# Altium schematic sheet RibbonCable.SchDoc(RibbonCable)
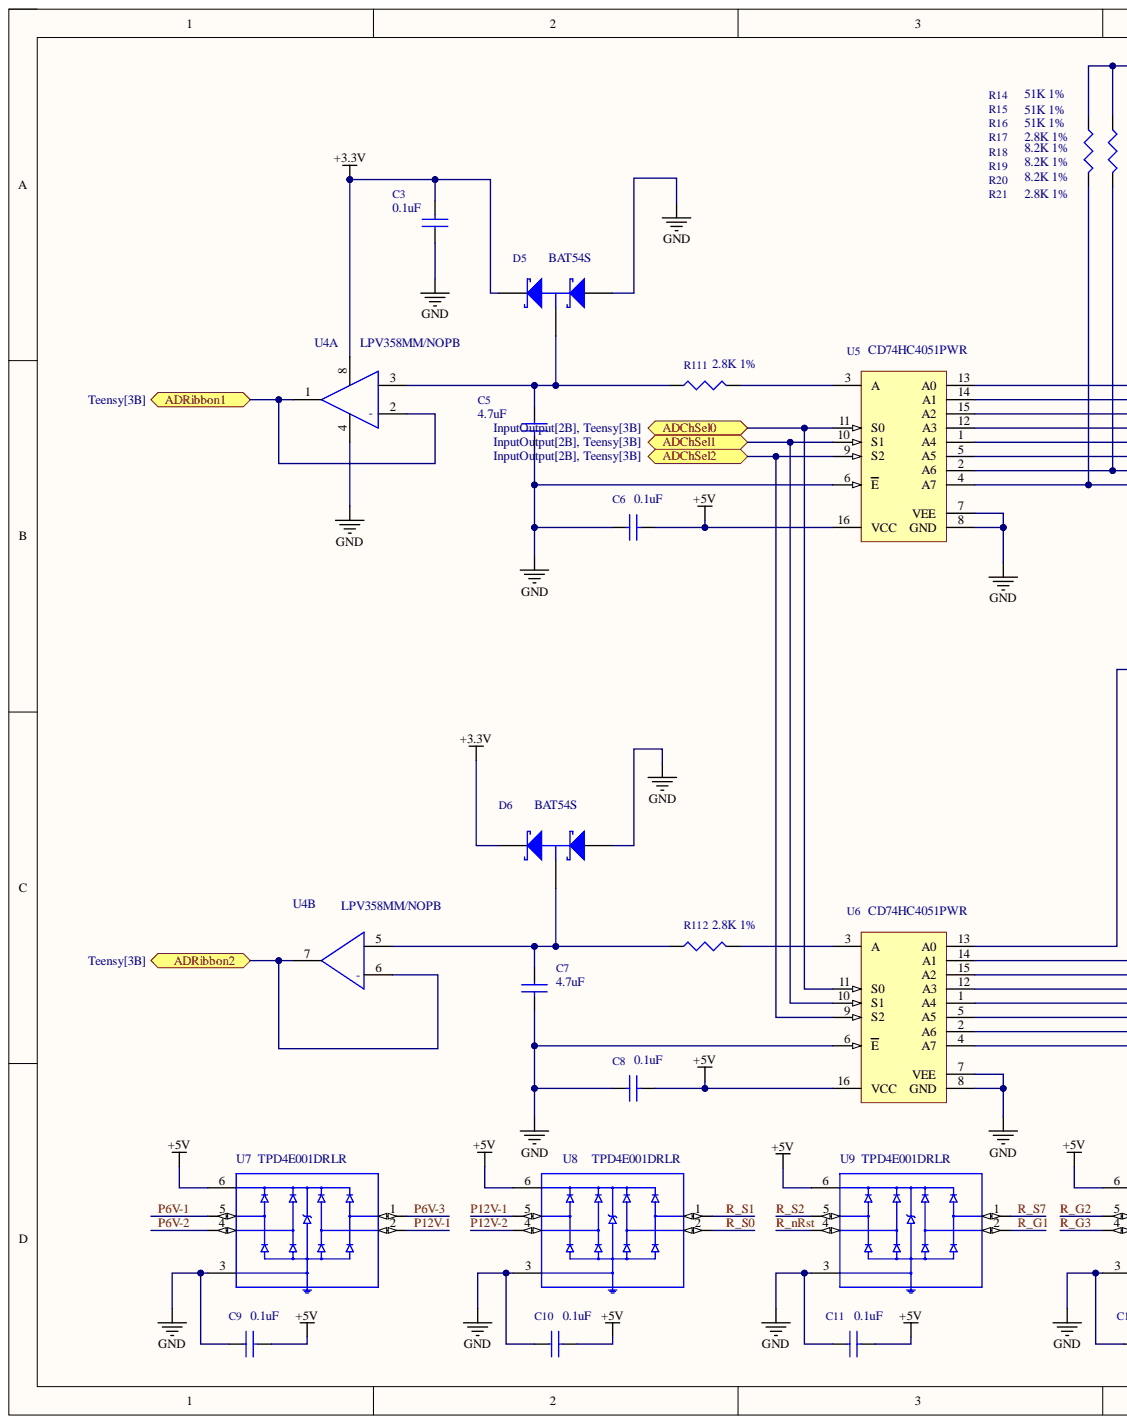
[diagram p0: RibbonCable.SchDoc, left]
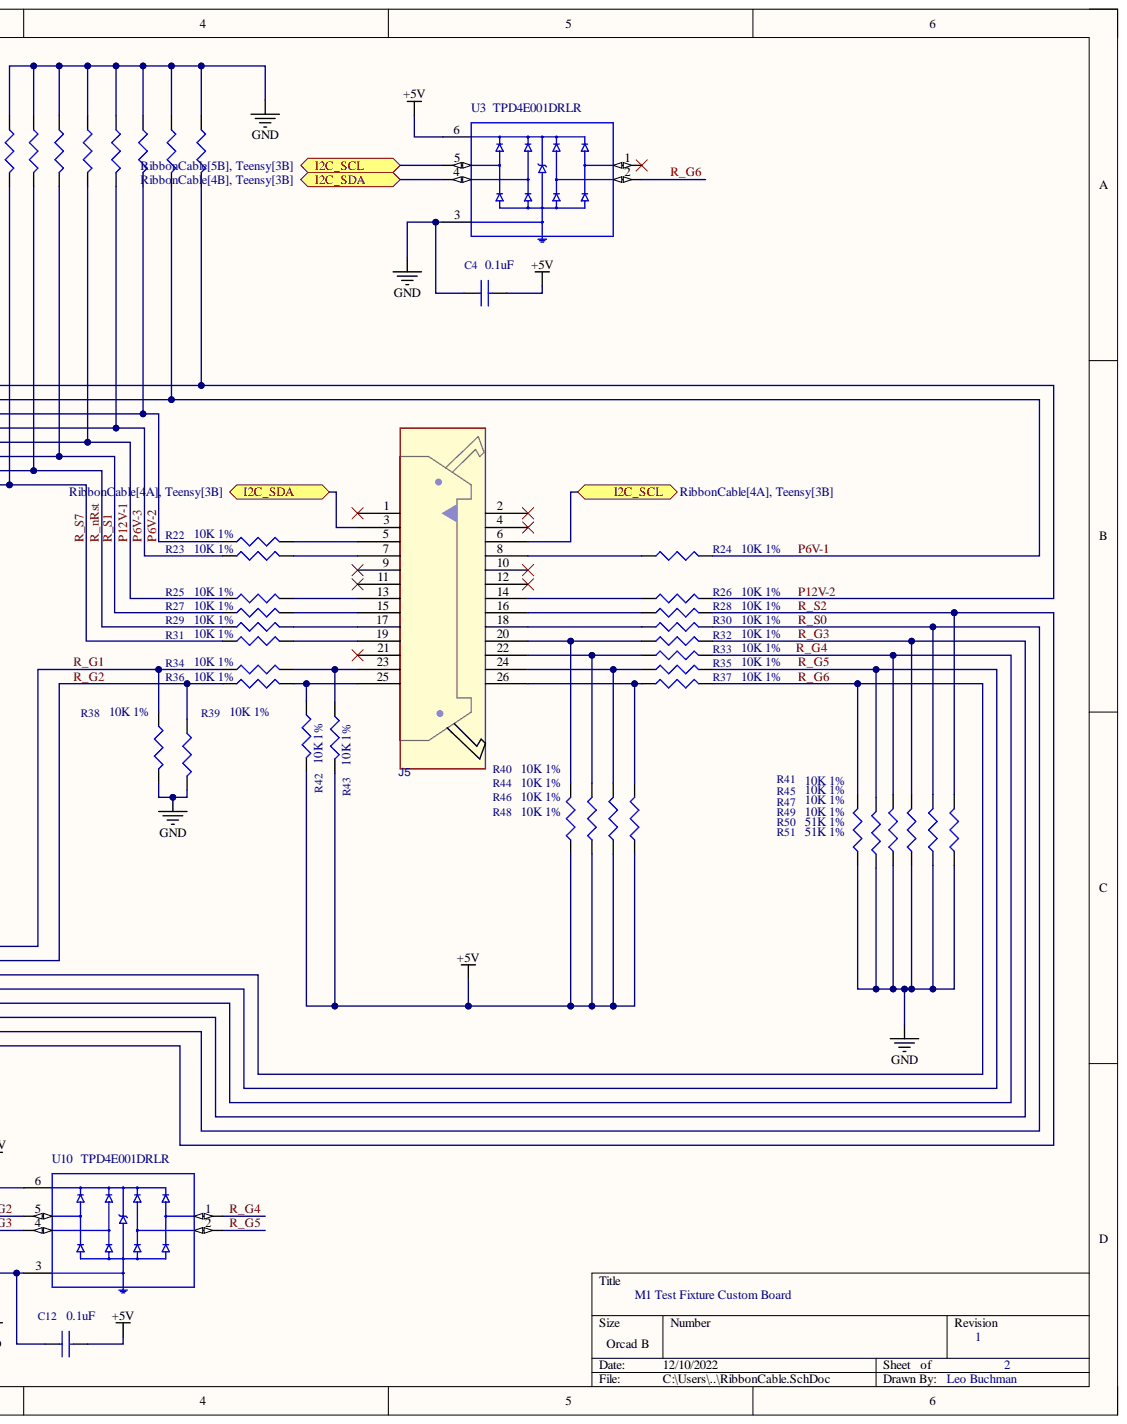
[diagram p1: RibbonCable.SchDoc, right]

## Parts
C3  0.1uF  [C0402]
C4  0.1uF  [C0402]
C5  4.7uF  [C0603]
C6  0.1uF  [C0402]
C7  4.7uF  [C0603]
C8  0.1uF  [C0402]
C9  0.1uF  [C0402]
C10  0.1uF  [C0402]
C11  0.1uF  [C0402]
C12  0.1uF  [C0402]
D5  BAT54S  [sot23-3]
D6  BAT54S  [sot23-3]
J5  N3429-5202RB  [CONN_N3429-5202RB]  (value not drawn)
R14  51K 1%  Tolerance: 1%  [R0402]
R15  51K 1%  [R0402]
R16  51K 1%  [R0402]
R17  2.8K 1%  [R0402]
R18  8.2K 1%  [R0402]
R19  8.2K 1%  [R0402]
R20  8.2K 1%  [R0402]
R21  2.8K 1%  [R0402]
R22  10K 1%  Tolerance: 1%  [R0402]
R23  10K 1%  Tolerance: 1%  [R0402]
R24  10K 1%  [R0402]
R25  10K 1%  Tolerance: 1%  [R0402]
R26  10K 1%  [R0402]
R27  10K 1%  Tolerance: 1%  [R0402]
R28  10K 1%  [R0402]
R29  10K 1%  Tolerance: 1%  [R0402]
R30  10K 1%  [R0402]
R31  10K 1%  Tolerance: 1%  [R0402]
R32  10K 1%  [R0402]
R33  10K 1%  [R0402]
R34  10K 1%  Tolerance: 1%  [R0402]
R35  10K 1%  [R0402]
R36  10K 1%  Tolerance: 1%  [R0402]
R37  10K 1%  [R0402]
R38  10K 1%  Tolerance: 1%  [R0402]
R39  10K 1%  [R0402]
R40  10K 1%  Tolerance: 1%  [R0402]
R41  10K 1%  Tolerance: 1%  [R0402]
R42  10K 1%  Tolerance: 1%  [R0402]
R43  10K 1%  Tolerance: 1%  [R0402]
R44  10K 1%  [R0402]
R45  10K 1%  [R0402]
R46  10K 1%  [R0402]
R47  10K 1%  [R0402]
R48  10K 1%  [R0402]
R49  10K 1%  [R0402]
R50  51K 1%  [R0402]
R51  51K 1%  [R0402]
R111  2.8K 1%  Tolerance: 1%  [R0402]
R112  2.8K 1%  Tolerance: 1%  [R0402]
U3  TPD4E001DRLR  [SOTFL50P160X60-6N]
U4  LPV358MM/NOPB  [MUA08A]  (parts A,B)
U5  CD74HC4051PWR  [16-TSSOP-4.4mm]
U6  CD74HC4051PWR  [16-TSSOP-4.4mm]
U7  TPD4E001DRLR  [SOTFL50P160X60-6N]
U8  TPD4E001DRLR  [SOTFL50P160X60-6N]
U9  TPD4E001DRLR  [SOTFL50P160X60-6N]
U10  TPD4E001DRLR  [SOTFL50P160X60-6N]

## Ports
ADChSel0, ADChSel1, ADChSel2, ADRibbon1, ADRibbon2, I2C_SCL, I2C_SDA

## Nets
+3.3V: C3.2 D5.2 D6.2 U4.8
+5V: C4.2 C6.2 C8.2 C9.2 C10.2 C11.2 C12.2 R40.1 R42.2 R43.2 R44.1 R46.1 R48.1 U3.6 U5.16(VCC) U6.16(VCC) U7.6 U8.6 U9.6 U10.6
GND: C3.1 C4.1 C5.2 C6.1 C7.2 C8.1 C9.1 C10.1 C11.1 C12.1 D5.1 D6.1 R14.1 R15.1 R16.1 R17.1 R18.1 R19.1 R20.1 R21.1 R38.2 R39.2 R41.1 R45.1 R47.1 R49.1 R50.1 R51.1 U3.3 U4.4 U5.6(E) U5.7(VEE) U5.8(GND) U6.6(E) U6.7(VEE) U6.8(GND) U7.3 U8.3 U9.3 U10.3
ADChSel0: U5.11(S0) U6.11(S0) ; port ADChSel0
ADChSel1: U5.10(S1) U6.10(S1) ; port ADChSel1
ADChSel2: U5.9(S2) U6.9(S2) ; port ADChSel2
ADRibbon1: U4.1 U4.2(-) ; port ADRibbon1
ADRibbon2: U4.6(-) U4.7 ; port ADRibbon2
I2C_SCL: J5.6 U3.5 ; port I2C_SCL
I2C_SDA: J5.3 U3.4 ; port I2C_SDA
P6V-1: R20.2 R24.2 U5.14(A1) U7.5
P6V-2: R19.2 R22.1 U5.15(A2) U7.4
P6V-3: R18.2 R23.1 U5.12(A3) U7.1
P12V-1: R17.2 R25.1 U5.1(A4) U7.2 U8.5
P12V-2: R21.2 R26.2 U5.13(A0) U8.4
R_G1: R34.1 R38.1 U6.13(A0) U9.2
R_G2: R36.1 R39.1 U6.14(A1) U10.5
R_G3: R32.2 R49.2 U6.5(A5) U10.4
R_G4: R33.2 R47.2 U6.1(A4) U10.1
R_G5: R35.2 R45.2 U6.12(A3) U10.2
R_G6: R37.2 R41.2 U3.2 U6.15(A2)
R_nRst: R15.2 R29.1 U5.2(A6) U9.4
R_S0: R30.2 R50.2 U6.2(A6) U8.2
R_S1: R16.2 R27.1 U5.5(A5) U8.1
R_S2: R28.2 R51.2 U6.4(A7) U9.5
R_S7: R14.2 R31.1 U5.4(A7) U9.1
NetC5_1: C5.1 D5.3 R111.1 U4.3
NetC7_1: C7.1 D6.3 R112.1 U4.5
NetJ5_5: J5.5 R22.2
NetJ5_7: J5.7 R23.2
NetJ5_8: J5.8 R24.1
NetJ5_13: J5.13 R25.2
NetJ5_14: J5.14 R26.1
NetJ5_15: J5.15 R27.2
NetJ5_16: J5.16 R28.1
NetJ5_17: J5.17 R29.2
NetJ5_18: J5.18 R30.1
NetJ5_19: J5.19 R31.2
NetJ5_20: J5.20 R32.1 R40.2
NetJ5_22: J5.22 R33.1 R44.2
NetJ5_23: J5.23 R34.2 R43.1
NetJ5_24: J5.24 R35.1 R46.2
NetJ5_25: J5.25 R36.2 R42.1
NetJ5_26: J5.26 R37.1 R48.2
NetR111_2: R111.2 U5.3(A)
NetR112_2: R112.2 U6.3(A)

Not connected: J5 1 2 4 9 10 11 12 21; U3 1

## Library data of the parts
C3 C4 C6 C8-C12 = Cap-00005: Cap Ceramic 0.1uF 50V X7R 10% Pad SMD 0402 125C Automotive T/R; Manufacturer: Murata; Manufacturer Part Number: GRT155R71H104KE01D; Database Table Name: Capacitors; Case Code (Imperial): 0402; Case Code (Metric): 1005; Case/Package: 0402; Category: Ceramic Capacitors; Component Kind: Standard; Dielectric Material: Ceramic; LCSC Part Number: C307331; Mount: Surface Mount; Number of Pins: 2; Part Name: Cap-00005; Pricing 1: 1=0.10000, 10=0.04700, 50=0.02580, 100=0.0211; RoHS: Yes; RoHS Compliant: Yes; Stock 1: 179948; Supplier 1: Digi-Key; Supplier Part Number 1: 490-GRT155R71H104KE01DCT-ND; Voltage Rating (DC): 50V; Library Name: Leo-Home-SQL-Lib.DbLib
C5 C7 = Cap-00001: CAP CER 4.7UF 16V X5R 0603; Manufacturer: Samsung; Manufacturer Part Number: CL10A475KO8NNNC; Database Table Name: Capacitors; Dielectric Material: Ceramic; Tolerance: 10%; Capacitance: 4.7uF; Case Code (Imperial): 0603; Case Code (Metric): 1608; Case/Package: 0603; Category: Ceramic Capacitors; Component Kind: Standard; ComponentLink1Description: https://datasheet.ciiva.com/25795/cl10a475ko8; ComponentLink1URL: https://datasheet.ciiva.com/25795/cl10a475ko8; ComponentLink2Description: https://datasheet.ciiva.com/26838/getdatashee; ComponentLink2URL: https://datasheet.ciiva.com/26838/getdatashee; ComponentLink3Description: https://datasheet.ciiva.com/29198/457663-da-0; ComponentLink3URL: https://datasheet.ciiva.com/29198/457663-da-0; Construction: Flat; Contact Plating: Tin; Depth: 0.8mm; Dielectric: X5R; Height: 0.8mm; LCSC Part Number: C19666; Length: 1.6mm; Material: Ceramic; Max Operating Temperature: 85°C; Min Operating Temperature: -55°C; Mount: Surface Mount; Number of Pins: 2; Package Quantity: 1; Packaging: Tape and Reel; Part Name: Cap-00001; Pricing 1: 1=0.13000, 10=0.09100, 50=0.05180, 100=0.0429; Radiation Hardening: No; RoHS: Yes; RoHS Compliant: Yes; Series: CL; Stock 1: 1593998; Supplier 1: Digi-Key; Supplier Part Number 1: 1276-1784-1-ND; Thickness: 0.035inch; Voltage: 16V; Voltage Rating: 16V; Width: 0.031inch; Library Name: Leo-Home-SQL-Lib.DbLib
D5 D6 = Diode-0009: DIODE SCHOTTKY 30V 150MA SOT23-3; Manufacturer: Sangdest Microelectronics; Database Table Name: Diodes; Elements: 2; Package Version: Rev. 12, 03/2016; Peak Reverse Current: 2uA; Recovery Time: 5ns; Component Kind: Standard; Component Type: Diodes; Datasheet URL: https://www.fairchildsemi.com/datasheets/BA/BAT54S.pdf; Datasheet Version: Rev. 2.3, 04/2016; LCSC Part Number: C47546; Lead Free: Lead Free; Manufacturer Part Number: BAT54S; Manufacturer URL: https://www.fairchildsemi.com/; Mount: Surface Mount; Mounting Technology: SM; Package Description: 3-Pin Small Outline Transistor, Body 2.92 x 1.325 mm, Pitch 0.95 mm; Package Reference: SOT23-3; Package URL: http://www.fairchildsemi.com/dwg/MA/MA03D.pdf; Part Name: Diode-0009; Pins: 3; Pricing 1: 1=0.43000, 10=0.35100, 100=0.18620, 500=0.122; Radiation Hardening: No; RoHS: Yes; Stock 1: 168252; Supplier 1: Digi-Key; Supplier Part Number 1: 1655-BAT54SCT-ND; Library Name: Leo-Home-SQL-Lib.DbLib
J5 = CON-0010: 3000 Series; 2.54mm Pitch 26 Way 2 Row Right; Manufacturer: 3M; ATTR: CONN HEADER LATCH/EJEC  RTA DUAL ROW 26POS; Closed End: No (Without); Connector Type: Male Pin; Current: 12A; Current Rating: 1A; Database Table Name: Connectors; Gender: Male; Interlock: Yes (With); Max Current Rating: 2A; Max Supply Voltage (AC): 300V; Number of Circuits: 8; Number of Contacts: 26; Number of Levels: 1; PKG: 2M54_13x2_TH_LATCH; Plating: Brass; Row Spacing: 0.1inch; Tail Length: 3.5mm; Thread Size: M2; Wire Gauge (Max): 30AWG; Category: Headers and Wire Housings; Color: Black; Component Kind: Standard; ComponentLink1Description: https://datasheet.ciiva.com/19461/1578947-194; ComponentLink1URL: https://datasheet.ciiva.com/19461/1578947-194; ComponentLink2Description: https://datasheet.ciiva.com/11754/0900766b807; ComponentLink2URL: https://datasheet.ciiva.com/11754/0900766b807; ComponentLink3Description: https://datasheet.ciiva.com/719/ts0772-719341; ComponentLink3URL: https://datasheet.ciiva.com/719/ts0772-719341; ComponentLink4Description: https://datasheet.ciiva.com/8051/ts0772-80510; ComponentLink4URL: https://datasheet.ciiva.com/8051/ts0772-80510; ComponentLink5Description: https://datasheet.ciiva.com/30156/eng-cs-1308; ComponentLink5URL: https://datasheet.ciiva.com/30156/eng-cs-1308; ComponentLink6Description: https://datasheet.ciiva.com/3141/eng-cs-13083; ComponentLink6URL: https://datasheet.ciiva.com/3141/eng-cs-13083; ComponentLink7Description: https://datasheet.ciiva.com/823/eng-cd-284391; ComponentLink7URL: https://datasheet.ciiva.com/823/eng-cd-284391; Contact Material: Copper Alloy,Copper; Contact Mating Length: 0.24inch; Contact Plating: Gold; Contact Type: Male Pin; Depth: 24.6mm; ELV: Compliant; Fastening Type: Latch; Flammability Rating: UL94 V-0; Height: 8.6mm; Housing Color: Black; Housing Material: Polychlorinated; LCSC Part Number: N/A; Lead Free: Lead Free; Length: 52.45mm; Manufacturer Part Number: N3429-5202RB; Max Operating Temperature: 105°C; Max Voltage Rating (AC): 300V; Min Operating Temperature: -55°C; Mount: Through Hole; Number of Positions: 26; Number of Positions Per Level: 8; Number of Rows: 2; Orientation: Right Angle; Package Quantity: 250; Packaging: Bulk; Part Name: CON-0010; Pitch: 2.54mm; Pricing 1: 1=5.34000, 10=4.85100, 100=4.27430, 500=3.633; Radiation Hardening: No; REACH SVHC: No SVHC; RoHS: Yes; RoHS Compliant: Yes; Screw Flange: No (Without); Screwless Terminal Block: No (Without); Series: 3000; Shrouded: Yes (With); Side Stackable: Yes (With); Stock 1: 185; Supplier 1: Digi-Key; Supplier Part Number 1: MHR26S-ND; Termination: Solder; Voltage: 300V; Voltage Rating: 300V; Wire Gauge (Min): 30AWG; Library Name: Leo-Home-SQL-Lib.DbLib
R14-R16 R50 R51 = Res-00011: Res Thick Film 0402 51K Ohm 1% 0.063W(1/16W) ±100ppm/C Pad SMD Automotive T/R; Manufacturer: Stackpole Electronics; Manufacturer Part Number: RMCF0402FT51K0; Database Table Name: Resistors; Max Power Dissipation: 63mW; Resistance: 51kR; Temperature Coefficient: 100ppm/°C; Case Code (Imperial): 0402; Case Code (Metric): 1005; Case/Package: 0402; Category: Chip SMD Resistors; Component Kind: Standard; ComponentLink1Description: http://components.arrow.com/part/dataview/535; ComponentLink1URL: http://components.arrow.com/part/dataview/535; ComponentLink2Description: https://www.seielect.com/catalog/SEI-RMCF_RMC; ComponentLink2URL: https://www.seielect.com/catalog/SEI-RMCF_RMC; ComponentLink3Description: https://www.seielect.com/Catalog/SEI-RMCF_RMC; ComponentLink3URL: https://www.seielect.com/Catalog/SEI-RMCF_RMC; ComponentLink4Description: https://www.seielect.com/catalog/SEI-Packagin; ComponentLink4URL: https://www.seielect.com/catalog/SEI-Packagin; Contact Plating: Tin,Matte; Features: Automotive AEC-Q200; Height: 0.016inch; LCSC Part Number: C25794; Length: 0.039inch; Max Operating Temperature: 155°C; Min Operating Temperature: -55°C; Mount: Surface Mount; Number of Terminations: 2; Packaging: Tape and Reel; Part Name: Res-00011; Power Rating: 63mW; Pricing 1: 1=0.10000, 10=0.01500, 100=0.00610, 1000=0.00; Radiation Hardening: No; Resistor Type: Thick Film; RoHS: Yes; RoHS Compliant: Yes; Series: RMCF; Stock 1: 588931; Supplier 1: Digi-Key; Supplier Part Number 1: RMCF0402FT51K0CT-ND; Width: 0.02inch; Library Name: Leo-Home-SQL-Lib.DbLib
  R15 R16 R50 R51: Tolerance: 1%
R17 R21 R111 R112 = Res-00008: Thick Film 0402 2.8K Ohm 1% 0.063W ±100ppm/°C Molded SMD Paper T/R; Manufacturer: Stackpole Electronics; Manufacturer Part Number: RMCF0402FT2K80; Database Table Name: Resistors; Max Power Dissipation: 63mW; Power Rating: 62.5mW; Resistance: 2.8kR; Case Code (Imperial): 0402; Case Code (Metric): 1005; Case/Package: 0402; Category: Chip SMD Resistors; Component Kind: Standard; ComponentLink1Description: https://www.seielect.com/catalog/SEI-RMCF_RMC; ComponentLink1URL: https://www.seielect.com/catalog/SEI-RMCF_RMC; ComponentLink2Description: https://www.seielect.com/Catalog/SEI-RMCF_RMC; ComponentLink2URL: https://www.seielect.com/Catalog/SEI-RMCF_RMC; ComponentLink3Description: https://www.seielect.com/catalog/SEI-Packagin; ComponentLink3URL: https://www.seielect.com/catalog/SEI-Packagin; Contact Plating: Tin,Matte; Features: Automotive AEC-Q200; Height: 0.016inch; LCSC Part Number: C62741; Lead Free: Lead Free; Length: 0.039inch; Max Operating Temperature: 155°C; Min Operating Temperature: -55°C; Mount: Surface Mount; Number of Terminations: 2; Packaging: Tape and Reel; Part Name: Res-00008; Pricing 1: 1=0.10000, 10=0.01500, 100=0.00610, 1000=0.00; Radiation Hardening: No; Resistor Type: Thick Film; RoHS: Yes; RoHS Compliant: Yes; Series: RMCF; Stock 1: 141882; Supplier 1: Digi-Key; Supplier Part Number 1: RMCF0402FT2K80CT-ND; Temperature Coefficient: 100ppm/°C; Width: 0.02inch; Library Name: Leo-Home-SQL-Lib.DbLib
  R17 R21: Tolerance: 1%
R18-R20 = Res-00012: Res Thick Film 0402 8.2K Ohm 5% 0.063W ±100ppm/°C Molded SMD Paper T/R; Manufacturer: Stackpole Electronics; Manufacturer Part Number: RMCF0402JT8K20; Database Table Name: Resistors; Max Power Dissipation: 63mW; Resistance: 8.2kR; Case Code (Imperial): 0402; Case Code (Metric): 1005; Case/Package: 0402; Category: Chip SMD Resistors; Component Kind: Standard; ComponentLink1Description: https://www.seielect.com/Catalog/SEI-RMCF_RMC; ComponentLink1URL: https://www.seielect.com/Catalog/SEI-RMCF_RMC; ComponentLink2Description: https://www.seielect.com/catalog/sei-rmcf_rmc; ComponentLink2URL: https://www.seielect.com/catalog/sei-rmcf_rmc; ComponentLink3Description: https://www.seielect.com/catalog/SEI-RMCF_RMC; ComponentLink3URL: https://www.seielect.com/catalog/SEI-RMCF_RMC; ComponentLink4Description: http://www.seielect.com/Catalog/Sei-RMC.pdf?s; ComponentLink4URL: http://www.seielect.com/Catalog/Sei-RMC.pdf?s; Contact Plating: Tin,Matte; Features: Automotive AEC-Q200; Height: 0.016inch; LCSC Part Number: C25924; Lead Free: Lead Free; Length: 0.039inch; Max Operating Temperature: 155°C; Min Operating Temperature: -55°C; Mount: Surface Mount; Number of Terminations: 2; Packaging: Tape and Reel; Part Name: Res-00012; Power Rating: 63mW; Pricing 1: 1=0.10000, 10=0.01200, 100=0.00470, 1000=0.00; Radiation Hardening: No; Resistor Type: Thick Film; RoHS: Yes; RoHS Compliant: Yes; Series: RMCF; Size Code: 0402; Stock 1: 9938; Supplier 1: Digi-Key; Supplier Part Number 1: 738-RMCF0402JT8K20CT-ND; Temperature Coefficient: 100ppm/°C; Tolerance: 5%; Width: 0.02inch; Library Name: Leo-Home-SQL-Lib.DbLib
R22-R49 = Res-00002: Thick Film 0402 10K OHM 1% 1/16W 0402; Manufacturer: Yageo; Manufacturer Part Number: RC0402FR-0710KP; Database Table Name: Resistors; Max Power Dissipation: 63mW; Power Rating: 62.5mW; Temperature Coefficient: 150ppm/°C; Case Code (Imperial): 0402; Case Code (Metric): 1005; Case/Package: 0402; Category: Chip SMD Resistors; Component Kind: Standard; ComponentLink1Description: http://www.yageo.com/NewPortal/yageodocoutput; ComponentLink1URL: http://www.yageo.com/NewPortal/yageodocoutput; Features: Moisture Resistant; Height: 0.016inch; LCSC Part Number: C25744; Length: 0.039inch; Max Operating Temperature: 155°C; Min Operating Temperature: -55°C; Mount: Surface Mount; Number of Terminations: 2; Packaging: Tape and Reel; Part Name: Res-00002; Pricing 1: 1=0.10000, 10=0.03600, 100=0.01450, 1000=0.00; Resistance: 10k; Resistor Type: Thick Film; RoHS: Yes; RoHS Compliant: Yes; Stock 1: 161500; Supplier 1: Digi-Key; Supplier Part Number 1: YAG1249CT-ND; Width: 0.02inch; Library Name: Leo-Home-SQL-Lib.DbLib
  R24 R26 R28 R30 R32 R33 R35 R37 R39 R44-R49: Tolerance: 1%
U3 U7-U10 = Diode-0012: TVS DIODE 5.5VWM SOT6; Manufacturer: Texas Instruments; Breakdown Voltage: 11V; Capacitance: 1.5pF; Clamping Voltage: 105.5V; Database Table Name: Diodes; Direction: Unidirectional; Interface: LVDS,USB,Ethernet; Leakage Current: 1nA; Max Power Dissipation: 100W; Number of Bidirectional Channels: 4; Operating Supply Voltage: 5.5V; Peak Pulse Current: 5.5A; Power Line Protection: Yes; Case/Package: SOT; Category: ESD and Circuit Protection ICs; Component Kind: Standard; ComponentLink1Description: https://datasheet.ciiva.com/5839/1535398-5839; ComponentLink1URL: https://datasheet.ciiva.com/5839/1535398-5839; ComponentLink2Description: https://datasheet.ciiva.com/22696/1831342-226; ComponentLink2URL: https://datasheet.ciiva.com/22696/1831342-226; ComponentLink3Description: https://datasheet.ciiva.com/1219/tpd4e001-121; ComponentLink3URL: https://datasheet.ciiva.com/1219/tpd4e001-121; ComponentLink4Description: https://datasheet.ciiva.com/15134/getdatashee; ComponentLink4URL: https://datasheet.ciiva.com/15134/getdatashee; ComponentLink5Description: https://datasheet.ciiva.com/7659/1853592-7659; ComponentLink5URL: https://datasheet.ciiva.com/7659/1853592-7659; ComponentLink6Description: https://datasheet.ciiva.com/338/tpd4e001-3380; ComponentLink6URL: https://datasheet.ciiva.com/338/tpd4e001-3380; ComponentLink7Description: https://datasheet.ciiva.com/338/tpd4e001-3380; ComponentLink7URL: https://datasheet.ciiva.com/338/tpd4e001-3380; ComponentLink8Description: https://datasheet.ciiva.com/338/tpd4e001-3380; ComponentLink8URL: https://datasheet.ciiva.com/338/tpd4e001-3380; ComponentLink9Description: https://datasheet.ciiva.com/14423/tpd4e001-14; ComponentLink9URL: https://datasheet.ciiva.com/14423/tpd4e001-14; Contact Plating: Gold; Depth: 1.2mm; Height: 0.55mm; LCSC Part Number: C527516; Lead Free: Lead Free; Length: 1.6mm; Manufacturer Part Number: TPD4E001DRLR; Max Breakdown Voltage: 11V; Max Operating Temperature: 85°C; Min Breakdown Voltage: 11V; Min Operating Temperature: -40°C; Mount: Surface Mount; Number of Channels: 4; Number of Pins: 6; Package Quantity: 1; Packaging: Tape and Reel; Part Name: Diode-0012; Peak Pulse Power: 100W; Polarity: Unidirectional; Pricing 1: 1=0.66000, 10=0.58100, 100=0.44520, 500=0.351; Radiation Hardening: No; REACH SVHC: No SVHC; Reverse Standoff Voltage: 5.5V; RoHS: Yes; RoHS Compliant: Yes; Series: -; Stock 1: 42388; Supplier 1: Digi-Key; Supplier Part Number 1: 296-21887-1-ND; Termination: SMD/SMT; Weight: 9.9E-05oz; Width: 1.2mm; Working Voltage: 5.5V; Library Name: Leo-Home-SQL-Lib.DbLib
U4 = amp-00002: Op Amp Dual Micropower Amplifier R-R O/P 5V 8; Manufacturer: TI National Semiconductor; Amplifier Type: GENERAL PURPOSE; Bandwidth: 152kHz; Common Mode Rejection Ratio: 50dB; Database Table Name: Amplifiers; Dual Supply Voltage: 9V; Input Bias Current: 2nA; Input Offset Voltage (Vos): 1.5mV; Max Dual Supply Voltage: 16V; Max Frequency: 1kHz; Min Dual Supply Voltage: 1.5V; Min Supply Voltage: 2.7V; Nominal Supply Current: 15uA; Number of Amplifiers: 2; Operating Supply Voltage: 3V; Output Current: 2mA; Output Current per Channel: 16mA; Slew Rate: 0.1V/µs; Voltage Gain: 100dB; Case/Package: VSSOP; Category: Amplifiers - Op Amps, Buffer, Instrumentation; Component Kind: Standard; ComponentLink1Description: https://datasheet.ciiva.com/6627/lpv321-n-662; ComponentLink1URL: https://datasheet.ciiva.com/6627/lpv321-n-662; ComponentLink2Description: https://datasheet.ciiva.com/6627/lpv321-n-662; ComponentLink2URL: https://datasheet.ciiva.com/6627/lpv321-n-662; ComponentLink3Description: https://datasheet.ciiva.com/6627/lpv321-n-662; ComponentLink3URL: https://datasheet.ciiva.com/6627/lpv321-n-662; Contact Plating: Tin; Gain Bandwidth Product: 152kHz; Height: 0.95mm; LCSC Part Number: C133971; Lead Free: Lead Free; Length: 3.1mm; Manufacturer Part Number: LPV358MM/NOPB; Max Operating Temperature: 85°C; Max Supply Voltage: 5V; Min Operating Temperature: -40°C; Mount: Surface Mount; Number of Channels: 2; Number of Circuits: 2; Number of Elements: 2; Number of Pins: 8; Operating Supply Current: 15uA; Package Quantity: 1000; Packaging: Tape and Reel; Part Name: amp-00002; Power Supply Rejection Ratio (PSRR): 50dB; Pricing 1: 1=1.43000, 10=1.27600, 25=1.21080, 100=0.9947; Radiation Hardening: No; REACH SVHC: No SVHC; RoHS: Yes; RoHS Compliant: Yes; Stock 1: 8722; Supplier 1: Digi-Key; Supplier Part Number 1: LPV358MM/NOPBCT-ND; Technology: BICMOS; Unity Gain Bandwidth Product: 152kHz; Width: 3.1mm; Library Name: Leo-Home-SQL-Lib.DbLib
U5 U6 = AIC-0001: 5-V, 8: 1, 1-channel analog mutliplexer 16-TS; Manufacturer: Texas Instruments; Bandwidth: 180MHz; Bandwidth(Max)(MHz): 180; Configuration: 1 X 1：8 MUX; Crosstalk: Yes; Database Table Name: Analog-ICs; Dual Supply Voltage: 3V; High Level Output Current: 25mA; Logic Function: Multiplexer,Demultiplexer; Max Dual Supply Voltage: 5V; Max Supply Voltage: 6V; Min Dual Supply Voltage: 1V; Min Supply Voltage: 2V; Nominal Supply Current: 16uA; Number of Bits: 8; Number of Channels: 1; On-State Resistance: 130R; Output Type: CMOS; Polarity: Non-Inverting; Propagation Delay: 60ns; Rating: Catalog; Ron(Max)(Ohms): 130; Ron(Typ)(Ohms): 45; Supply Type: Single,Dual; Turn-Off Delay Time: 225ns; VCC(Max)(V): 6; VCC(Min)(V): 2; Case/Package: TSSOP; Category: Decoders and Multiplexers; Code_JEDEC: MS-012 variation AC; Component Kind: Standard; ComponentLink1Description: https://datasheet.ciiva.com/15127/getdatashee; ComponentLink1URL: https://datasheet.ciiva.com/15127/getdatashee; ComponentLink2Description: https://datasheet.ciiva.com/27092/1326571-270; ComponentLink2URL: https://datasheet.ciiva.com/27092/1326571-270; ComponentLink3Description: https://datasheet.ciiva.com/1514/1789854-1514; ComponentLink3URL: https://datasheet.ciiva.com/1514/1789854-1514; ComponentLink4Description: https://datasheet.ciiva.com/1171/1633734-1171; ComponentLink4URL: https://datasheet.ciiva.com/1171/1633734-1171; ComponentLink5Description: https://datasheet.ciiva.com/1171/1633734-1171; ComponentLink5URL: https://datasheet.ciiva.com/1171/1633734-1171; ComponentLink6Description: https://datasheet.ciiva.com/2978/cd74hct4053-; ComponentLink6URL: https://datasheet.ciiva.com/2978/cd74hct4053-; ComponentLink7Description: https://datasheet.ciiva.com/46391/1840262-463; ComponentLink7URL: https://datasheet.ciiva.com/46391/1840262-463; Contact Plating: Gold; DatasheetVersion: SCHS122J; Generic Part Number: CD74HC4051; Height: 1.15mm; LCSC Part Number: C352826; Lead Free: Lead Free; Length: 5mm; Low Level Output Current: 25mA; Manufacturer Part Number: CD74HC4051PWR; Max Operating Temperature: 125°C; Max Output Current: 25mA; Min Operating Temperature: -55°C; Mount: Surface Mount; Number of Circuits: 1; Number of Inputs: 8; Number of Outputs: 1; Number of Pins: 16; Number of Switches: 1; Operating Temperature Range(C): -55 to 125; Package Quantity: 1; PackageReference: D0016A; PackageVersion: http://www.ti.com/lit/ml/mpds178g/mpds178g.pdf; Packaging: Tape and Reel; Part Name: AIC-0001; Pricing 1: 1=0.33420, 10=0.26780, 30=0.23940, 100=0.2039; Radiation Hardening: No; REACH SVHC: No SVHC; RoHS: Yes; RoHS Compliant: Yes; Series: 74HC; Stock 1: 12645; Supplier 1: Newark; Supplier Part Number 1: 29AH3620; Supply Current: 16uA; Termination: SMD/SMT; Turn-On Delay Time: 225ns; Weight: 0.002183oz; Width: 4.4mm; Library Name: Leo-Home-SQL-Lib.DbLib
